# Altium schematic sheet 05_USBHUB.SchDoc(05_USBHUB)
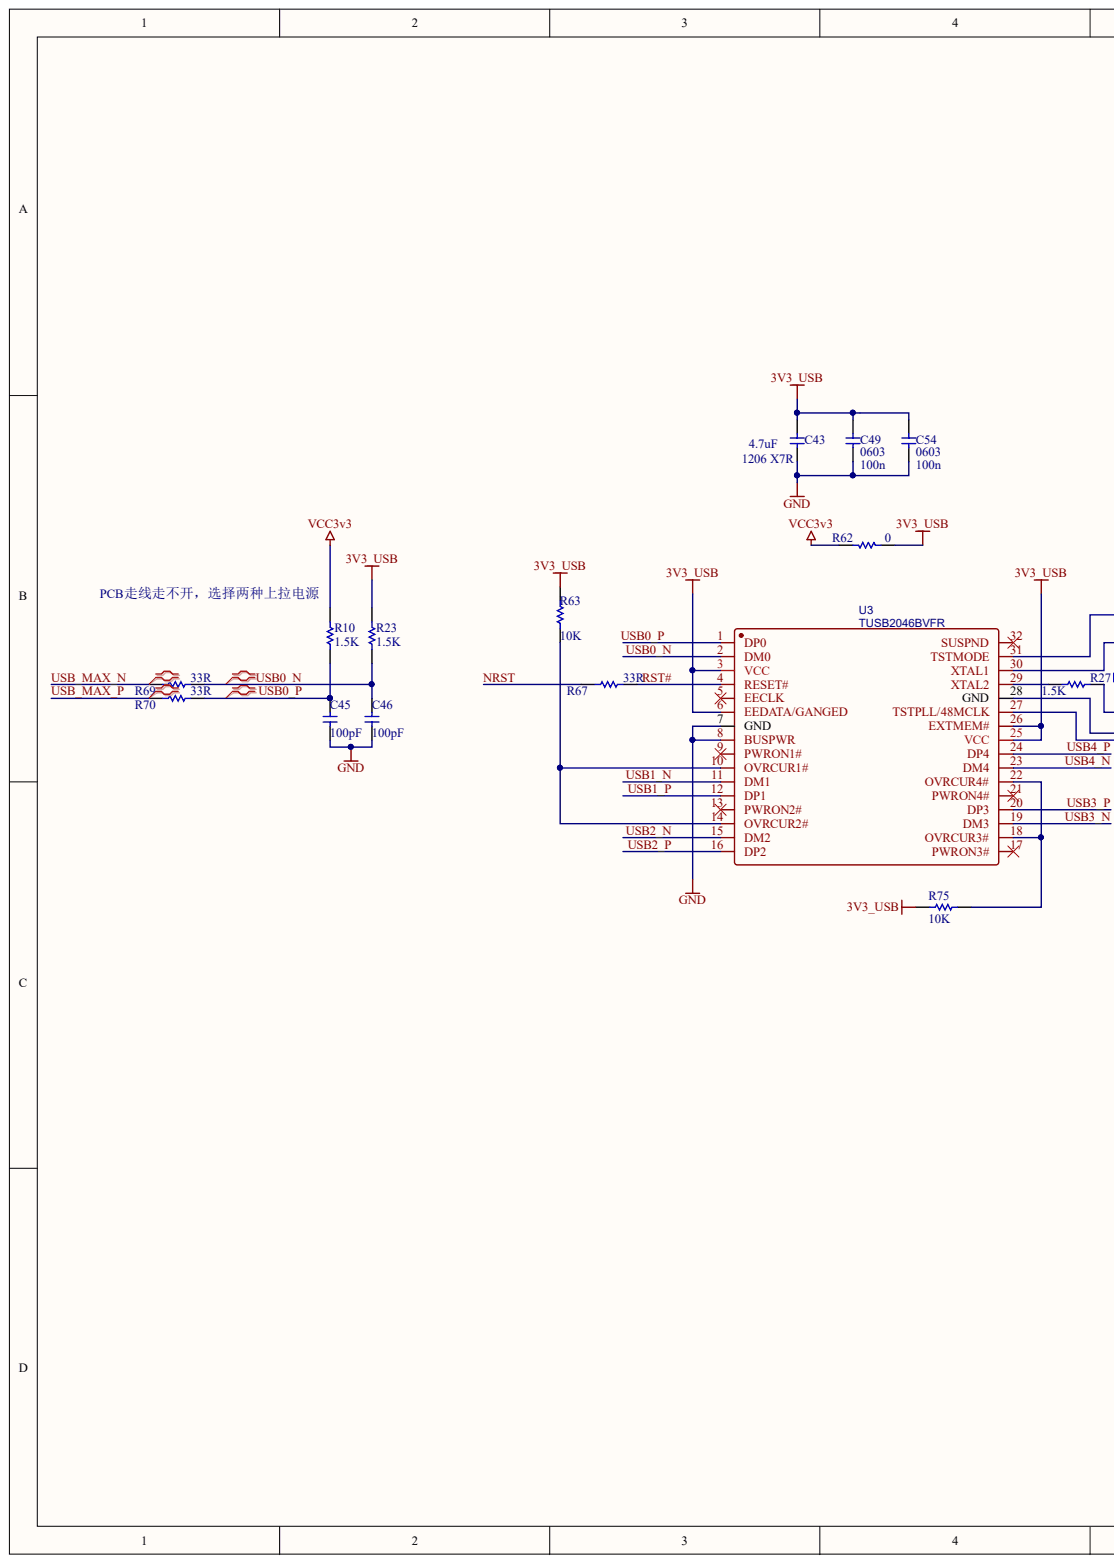
[diagram p0: 05_USBHUB.SchDoc, left]
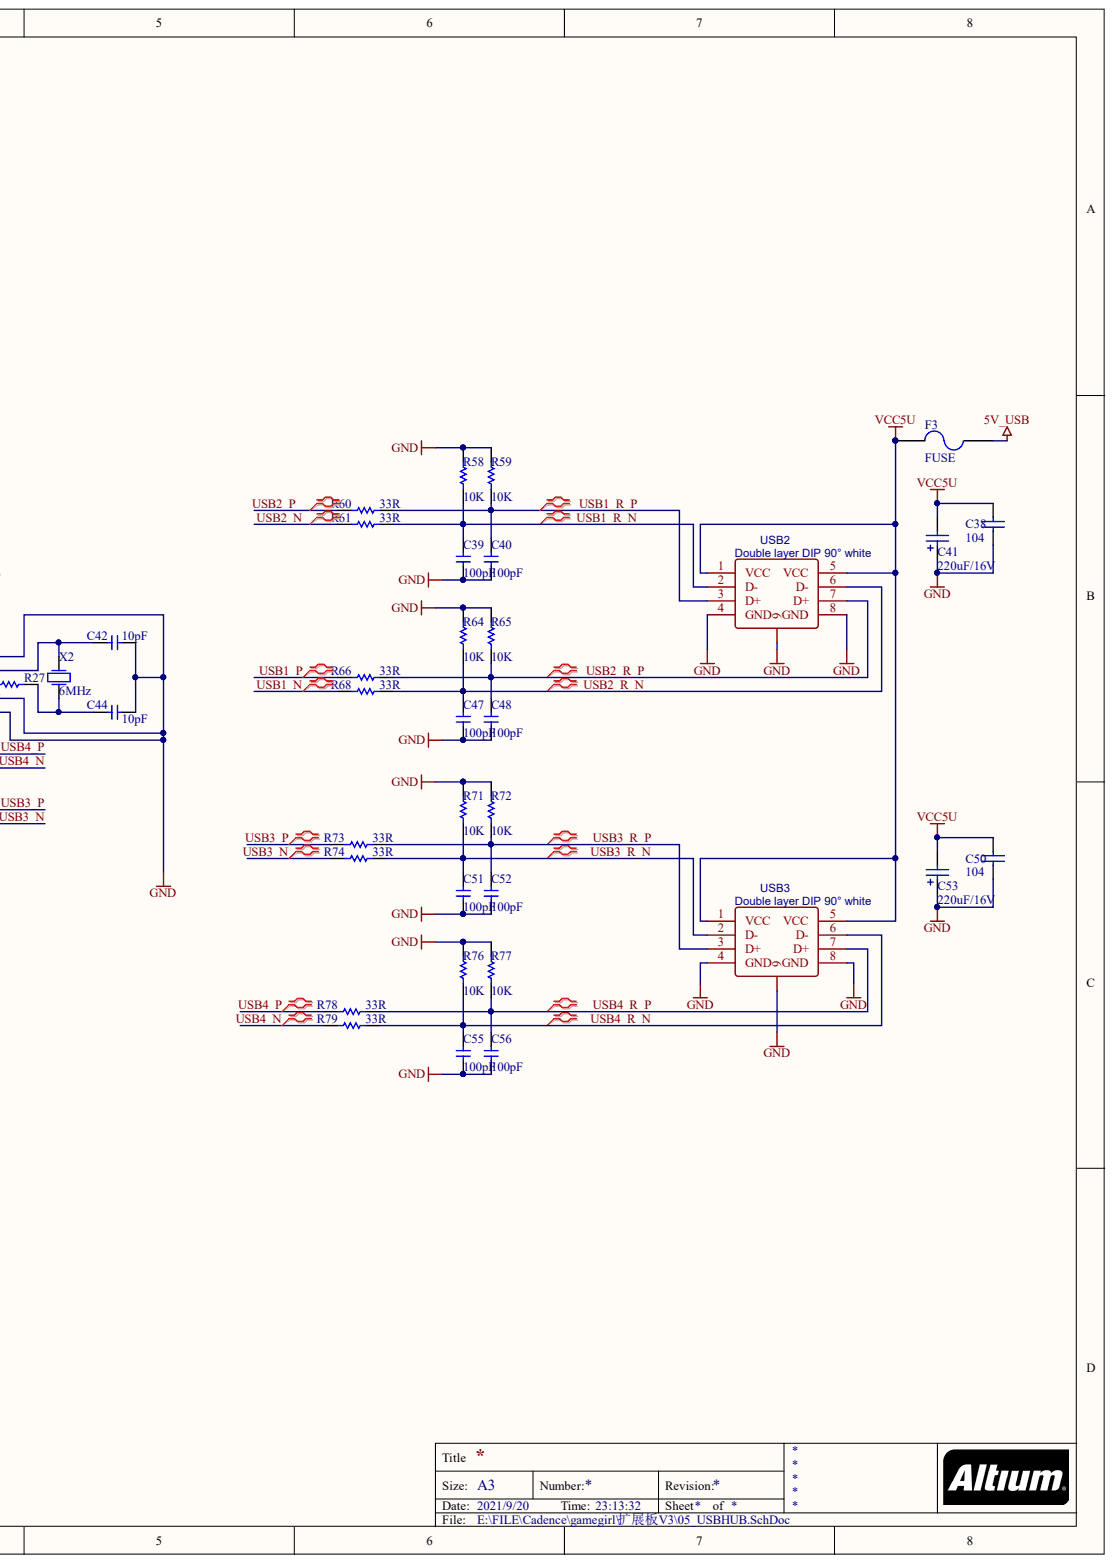
[diagram p1: 05_USBHUB.SchDoc, right]

## Parts
C38  value 104  [0603]  (hidden comment: Cap1)
C39  value 100pF  [0603]  (hidden comment: 0603)
C40  value 100pF  [0603]  (hidden comment: 0603)
C41  value 220uF/16V  [0805]  (hidden comment: Cap_Pol)
C42  value 10pF  [0603]  (hidden comment: 0603)
C43  1206 X7R  value 4.7uF  [0805]
C44  value 10pF  [0603]  (hidden comment: 0603)
C45  value 100pF  [0603]  (hidden comment: 0603)
C46  value 100pF  [0603]  (hidden comment: 0603)
C47  value 100pF  [0603]  (hidden comment: 0603)
C48  value 100pF  [0603]  (hidden comment: 0603)
C49  0603  value 100n  [0603]
C50  value 104  [0603]  (hidden comment: Cap1)
C51  value 100pF  [0603]  (hidden comment: 0603)
C52  value 100pF  [0603]  (hidden comment: 0603)
C53  value 220uF/16V  [0805]  (hidden comment: Cap_Pol)
C54  0603  value 100n  [0603]
C55  value 100pF  [0603]  (hidden comment: 0603)
C56  value 100pF  [0603]  (hidden comment: 0603)
F3  FUSE  [FUSE_1206]
R10  value 1.5K  [R0402]  (hidden comment: R0603)
R23  value 1.5K  [R0402]  (hidden comment: R0603)
R27  value 1.5K  [0603]  (hidden comment: R0603)
R58  10K  [0603]
R59  10K  [0603]
R60  33R  [R0402]
R61  33R  [R0402]
R62  value 0  [0603]  (hidden comment: 0603)
R63  10K  [0603]
R64  10K  [0603]
R65  10K  [0603]
R66  33R  [R0402]
R67  33R  [R0402]
R68  33R  [R0402]
R69  33R  [R0402]
R70  33R  [R0402]
R71  10K  [0603]
R72  10K  [0603]
R73  33R  [R0402]
R74  33R  [R0402]
R75  10K  [0603]
R76  10K  [0603]
R77  10K  [0603]
R78  33R  [R0402]
R79  33R  [R0402]
U3  TUSB2046BVFR  [LQFP-32_L7.0-W7.0-P0.80-LS9.0-TL]
USB2  Double layer DIP 90° white  [USB-A-TH_USB-M-51]
USB3  Double layer DIP 90° white  [USB-A-TH_USB-M-51]
X2  6MHz  [CRYSTAL_SMT]

## Nets
3V3_USB: C43.2 C49.2 C54.2 R23.1 R62.1 R63.1 R75.1 U3.3(VCC) U3.6(EEDATA/GANGED) U3.25(VCC) U3.26(EXTMEM#)
5V_USB: F3.2
GND: C38.1 C39.2 C40.2 C41.1 C42.1 C43.1 C44.1 C45.2 C46.2 C47.2 C48.2 C49.1 C50.1 C51.2 C52.2 C53.1 C54.1 C55.2 C56.2 R58.1 R59.1 R64.1 R65.1 R71.1 R72.1 R76.1 R77.1 U3.7(GND) U3.8(BUSPWR) U3.27(TSTPLL/48MCLK) U3.28(GND) U3.31(TSTMODE) USB2.4(GND) USB2.8(GND) USB2.9 USB3.4(GND) USB3.8(GND) USB3.9
VCC3V3: R10.1 R62.2
VCC5U: C38.2 C41.2 C50.2 C53.2 F3.1 USB2.1(VCC) USB2.5(VCC) USB3.1(VCC) USB3.5(VCC)
NRST: R67.2
RST#: R67.1 U3.4(RESET#)
USB0_N: C46.1 R23.2 R69.1 U3.2(DM0)
USB0_P: C45.1 R10.2 R70.1 U3.1(DP0)
USB1_N: R68.2 U3.11(DM1)
USB1_P: R66.2 U3.12(DP1)
USB1_R_N: C39.1 R58.2 R61.1 USB2.2(D-)
USB1_R_P: C40.1 R59.2 R60.1 USB2.3(D+)
USB2_N: R61.2 U3.15(DM2)
USB2_P: R60.2 U3.16(DP2)
USB2_R_N: C47.1 R64.2 R68.1 USB2.6(D-)
USB2_R_P: C48.1 R65.2 R66.1 USB2.7(D+)
USB3_N: R74.2 U3.19(DM3)
USB3_P: R73.2 U3.20(DP3)
USB3_R_N: C51.1 R71.2 R74.1 USB3.2(D-)
USB3_R_P: C52.1 R72.2 R73.1 USB3.3(D+)
USB4_N: R79.2 U3.23(DM4)
USB4_P: R78.2 U3.24(DP4)
USB4_R_N: C55.1 R76.2 R79.1 USB3.6(D-)
USB4_R_P: C56.1 R77.2 R78.1 USB3.7(D+)
USB_MAX_N: R69.2
USB_MAX_P: R70.2
NetC42_2: C42.2 U3.30(XTAL1) X2.2
NetC44_2: C44.2 R27.2 X2.1
NetR27_1: R27.1 U3.29(XTAL2)
NetR63_2: R63.2 U3.10(OVRCUR1#) U3.14(OVRCUR2#)
NetR75_2: R75.2 U3.18(OVRCUR3#) U3.22(OVRCUR4#)

Not connected: U3 5(EECLK) 9(PWRON1#) 13(PWRON2#) 17(PWRON3#) 21(PWRON4#) 32(SUSPND)

## Library data of the parts
C38 C50 = Cap1: Capacitor; Component Kind: Standard; LatestRevisionDate: 17-Jul-2002; LatestRevisionNote: Re-released for DXP Platform.; PackageReference: RAD-0.3; Published: 8-Jun-2000; Library Name: weifengdq.IntLib; Publisher: Altium Limited
C39 C40 C45-C49 C51 C52 C54-C56 = CAP_0603: 0603 footprint non-polorised capacitor; Component Kind: Standard; Library Name: Integrated Comp_lib.IntLib
C41 C53 = Cap_Pol: Capacitor; Component Kind: Standard; LatestRevisionDate: 17-Jul-2002; LatestRevisionNote: Re-released for DXP Platform.; PackageReference: RAD-0.3; Published: 8-Jun-2000; Library Name: weifengdq.IntLib; Publisher: Altium Limited
C42 C44 = CAP_0805: 0805 footprint non-polarised capacitor; Component Kind: Standard; Library Name: Integrated Comp_lib.IntLib
C43 = CAP_1206: 1206 footprint non-polorised capacitor; Component Kind: Standard; Library Name: Integrated Comp_lib.IntLib
R10 R23 R27 = R0603: Resistor; STUFF: NO; Component Kind: Standard; LatestRevisionDate: 17-Jul-2002; LatestRevisionNote: Re-released for DXP Platform.; Library Name: MYLIB.IntLib; PackageDescription: Axial Device, Thru-Hole; 2 Leads; 0.3 in Pin Spacing; PackageReference: AXIAL-0.3; Published: 8-Jun-2000; Signal Integrity: Res; Simulation: RESISTOR; Publisher: Altium Limited
R58-R61 R63-R79 = RES: Component Kind: Standard; Library Name: 电阻.IntLib
R62 = RES_0603: 0603 footprint resistor; Component Kind: Standard; Library Name: Integrated Comp_lib.IntLib
U3 = TUSB2046BVFR: BOM_Manufacturer: Texas Instruments; Contributor: LCSC; SMT Type: Extend; BOM_JLCPCB SMD: Yes; BOM_Manufacturer Part: TUSB2046BVFR; BOM_Supplier: LCSC; BOM_Supplier Part: C167642; Component Kind: Standard; spicePre: U; spiceSymbolName: TUSB2046BVFR
USB2 USB3 = Double layer DIP 90° white: BOM_Manufacturer: ValuePro; BOM_Manufacturer Part: Double layer DIP 90° white; BOM_Supplier: LCSC; BOM_Supplier Part: C2341; Component Kind: Standard; spicePre: U; spiceSymbolName: Double layer DIP 90° white
X2 = CRYSTAL_SMT: SMT crystal; Value: 18.432MHz; Component Kind: Standard; Library Name: ARM_projects.IntLib
F3 = FUSE: Component Kind: Standard; Library Name: 保险丝.IntLib
